# Altium schematic sheet Secondary.SchDoc
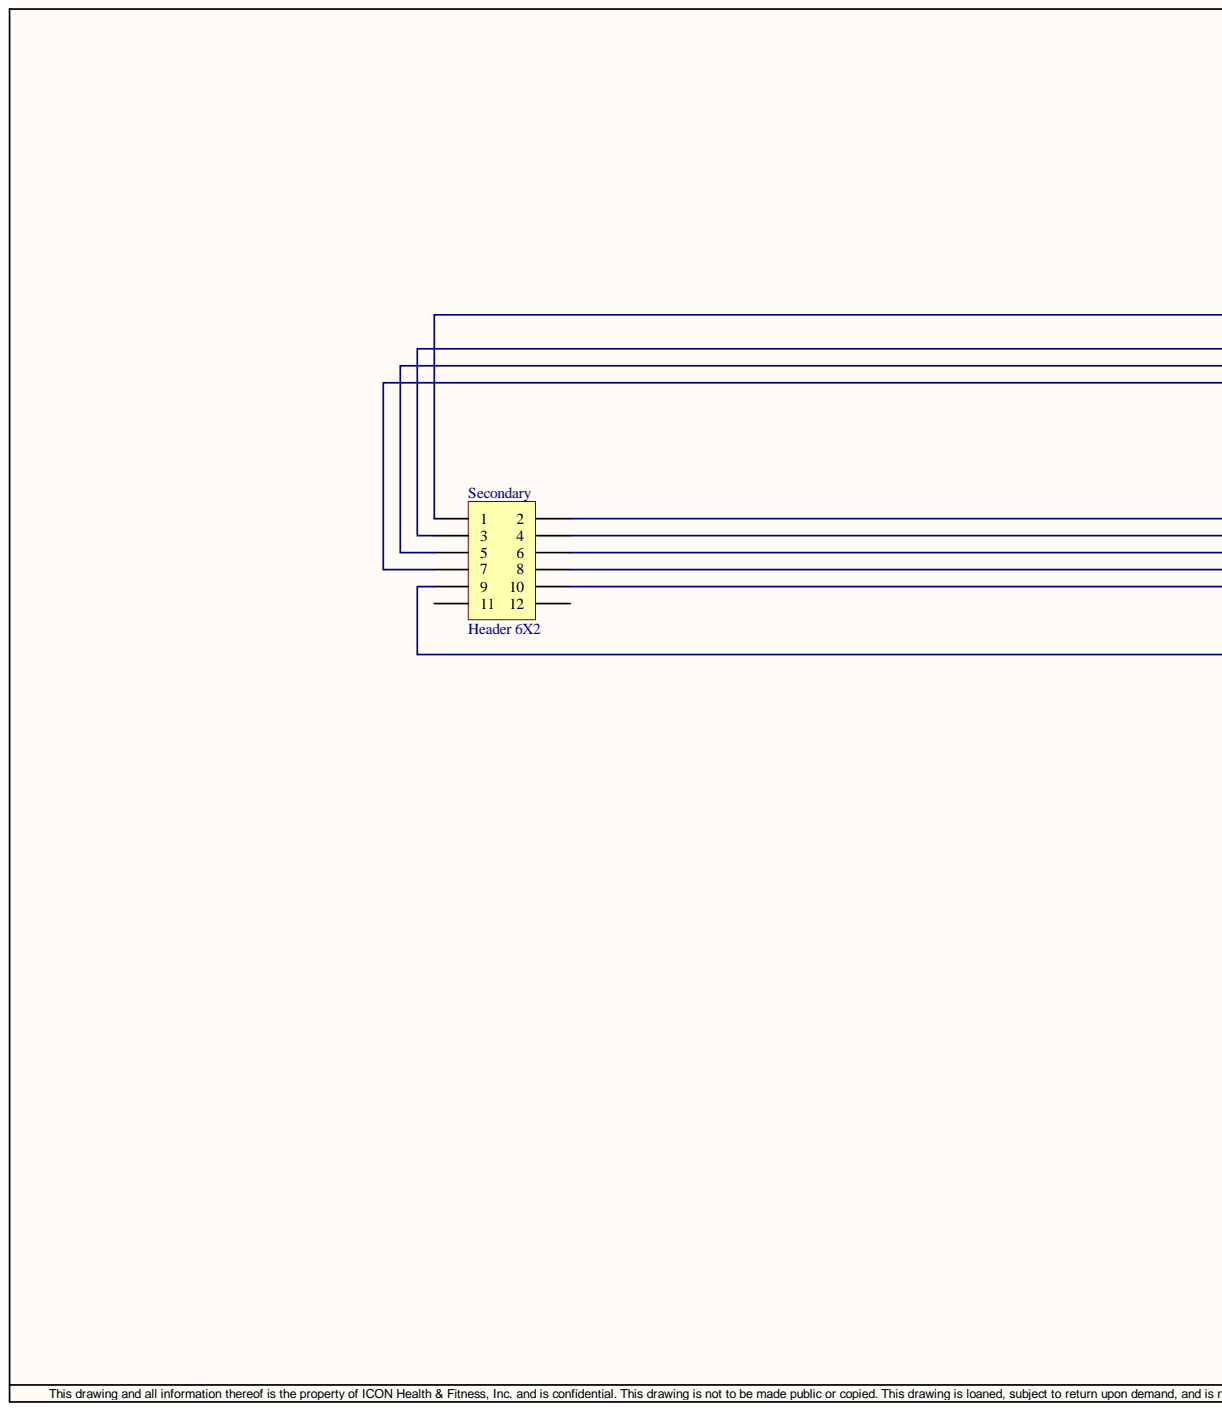
[diagram p0: Secondary.SchDoc, left]
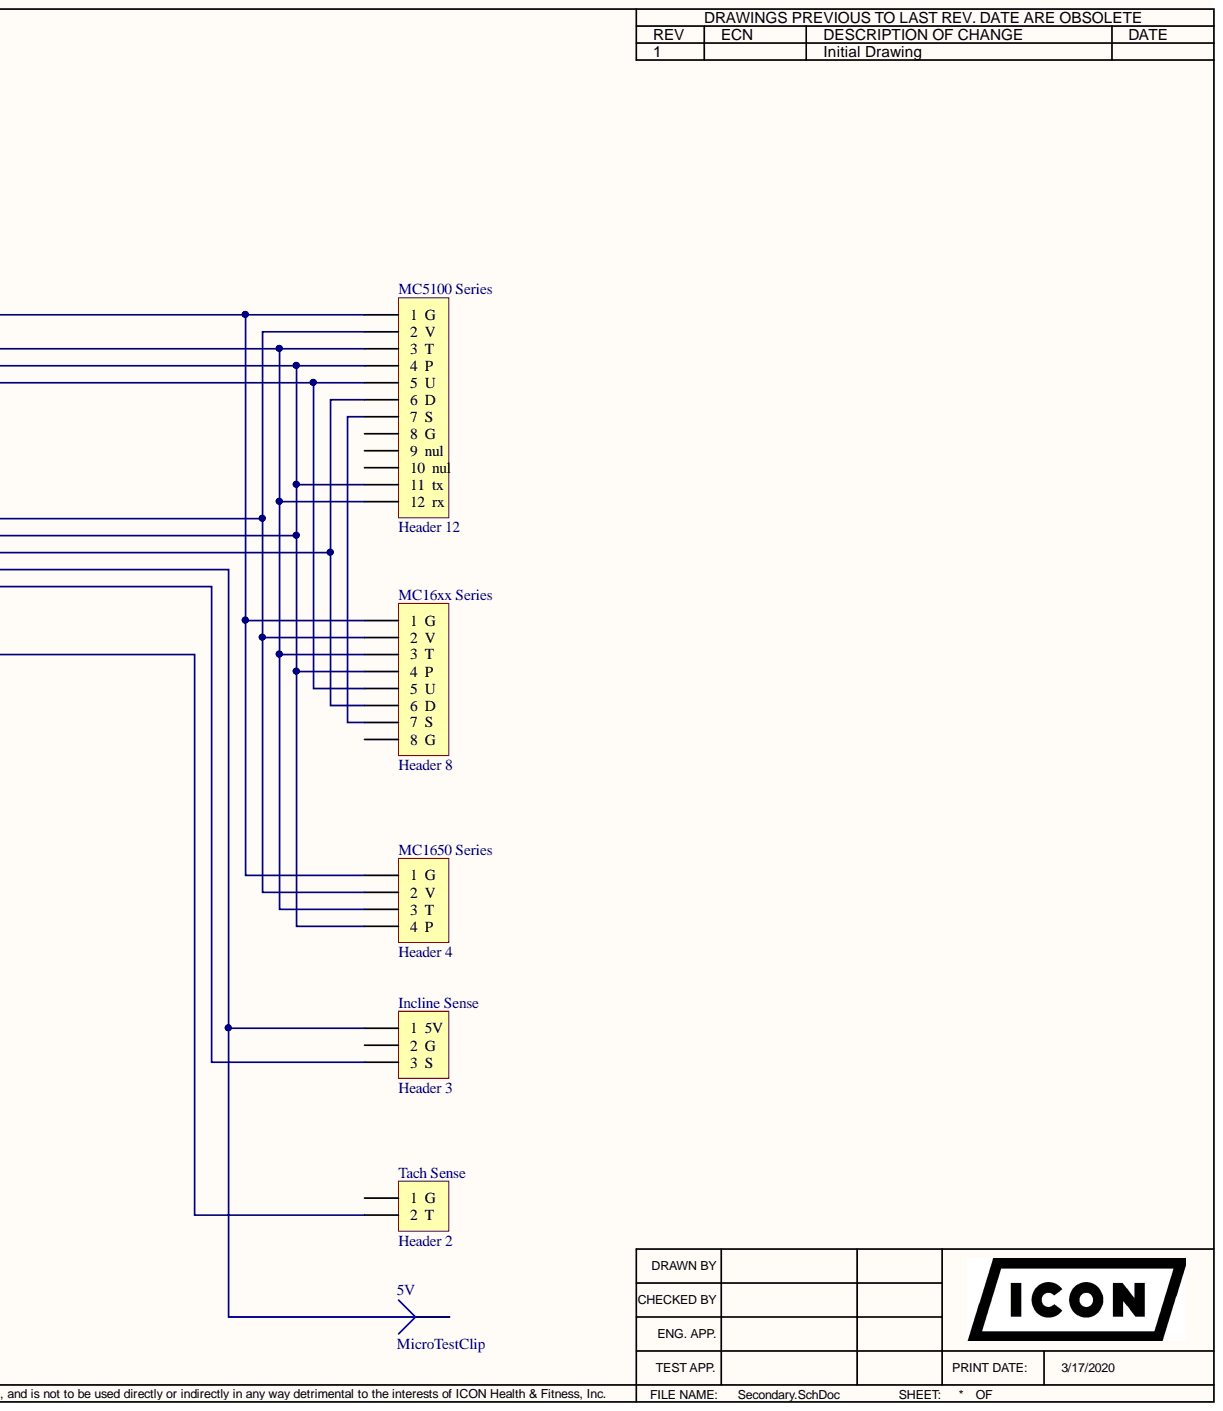
[diagram p1: Secondary.SchDoc, right]

## Parts
5V  MicroTestClip  [PIN1]
Incline_Sense  Header 3  [HDR1X3]
MC16xx_Series  Header 8  [HDR1X8]
MC1650_Series  Header 4  [HDR1X4]
MC5100_Series  Header 12  [HDR1X12]
Secondary  Header 6X2  [HDR2X6]
Tach_Sense  Header 2  [HDR1X2]

## Nets
Net5V_1: 5V.1 Incline_Sense.1 Secondary.8
NetIncline Sense_3: Incline_Sense.3 Secondary.10
NetMC16xx Series_1: MC16xx_Series.1 MC1650_Series.1 MC5100_Series.1 Secondary.1
NetMC16xx Series_2: MC16xx_Series.2 MC1650_Series.2 MC5100_Series.2 Secondary.2
NetMC16xx Series_3: MC16xx_Series.3 MC1650_Series.3 MC5100_Series.3 MC5100_Series.12 Secondary.3
NetMC16xx Series_4: MC16xx_Series.4 MC1650_Series.4(P) MC5100_Series.4 MC5100_Series.11 Secondary.4 Secondary.5
NetMC16xx Series_5: MC16xx_Series.5 MC5100_Series.5 Secondary.7
NetMC16xx Series_6: MC16xx_Series.6 MC5100_Series.6 Secondary.6
NetMC16xx Series_7: MC16xx_Series.7 MC5100_Series.7
NetSecondary_9: Secondary.9 Tach_Sense.2

Not connected: Incline_Sense 2; MC16xx_Series 8(G); MC5100_Series 8 9 10; Secondary 11 12; Tach_Sense 1

## Library data of the parts
5V = Socket: Component Kind: Standard; Description: Socket; LatestRevisionDate: 17-Jul-2002; LatestRevisionNote: Re-released for DXP Platform.; Library Name: Miscellaneous Connectors.IntLib; Signal Integrity: Connector; Publisher: Altium Limited
Incline_Sense = Header 3: Header, 3-Pin; Component Kind: Standard; LatestRevisionDate: 17-Jul-2002; LatestRevisionNote: Re-released for DXP Platform.; Library Name: Miscellaneous Connectors.IntLib; Signal Integrity: Connector; Publisher: Altium Limited
MC16xx_Series = Header 8: Header, 8-Pin; Component Kind: Standard; LatestRevisionDate: 17-Jul-2002; LatestRevisionNote: Re-released for DXP Platform.; Library Name: Miscellaneous Connectors.IntLib; Signal Integrity: Connector; Publisher: Altium Limited
MC1650_Series = Header 4: Header, 4-Pin; Component Kind: Standard; LatestRevisionDate: 17-Jul-2002; LatestRevisionNote: Re-released for DXP Platform.; Library Name: Miscellaneous Connectors.IntLib; Signal Integrity: Connector; Publisher: Altium Limited
MC5100_Series = Header 12: Header, 12-Pin; Component Kind: Standard; LatestRevisionDate: 17-Jul-2002; LatestRevisionNote: Re-released for DXP Platform.; Library Name: Miscellaneous Connectors.IntLib; Signal Integrity: Connector; Publisher: Altium Limited
Secondary = Header 6X2: Header, 6-Pin, Dual row; Component Kind: Standard; LatestRevisionDate: 17-Jul-2002; LatestRevisionNote: Re-released for DXP Platform.; Library Name: Miscellaneous Connectors.IntLib; Signal Integrity: Connector; Publisher: Altium Limited
Tach_Sense = Header 2: Header, 2-Pin; Component Kind: Standard; LatestRevisionDate: 17-Jul-2002; LatestRevisionNote: Re-released for DXP Platform.; Library Name: Miscellaneous Connectors.IntLib; Signal Integrity: Connector; Publisher: Altium Limited
